# Altium schematic sheet Completo.SchDoc(Completo)
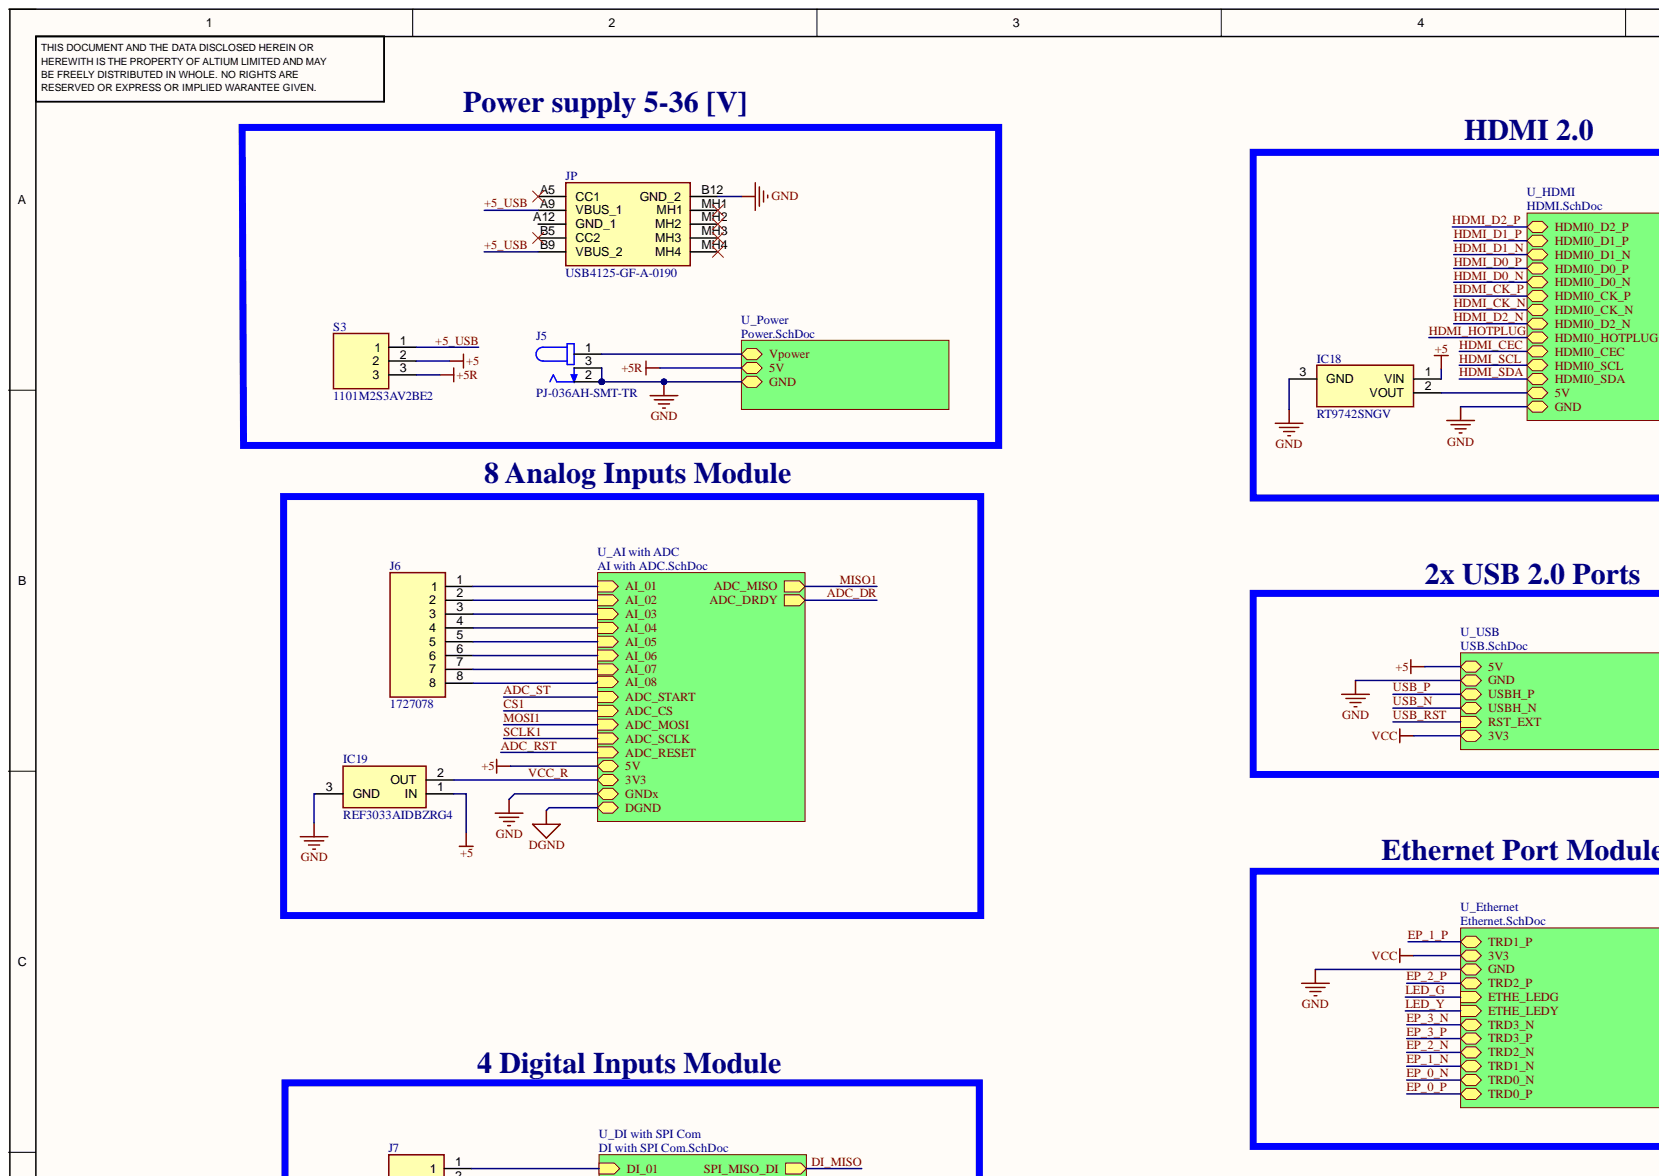
[diagram p0: Completo.SchDoc, top left]
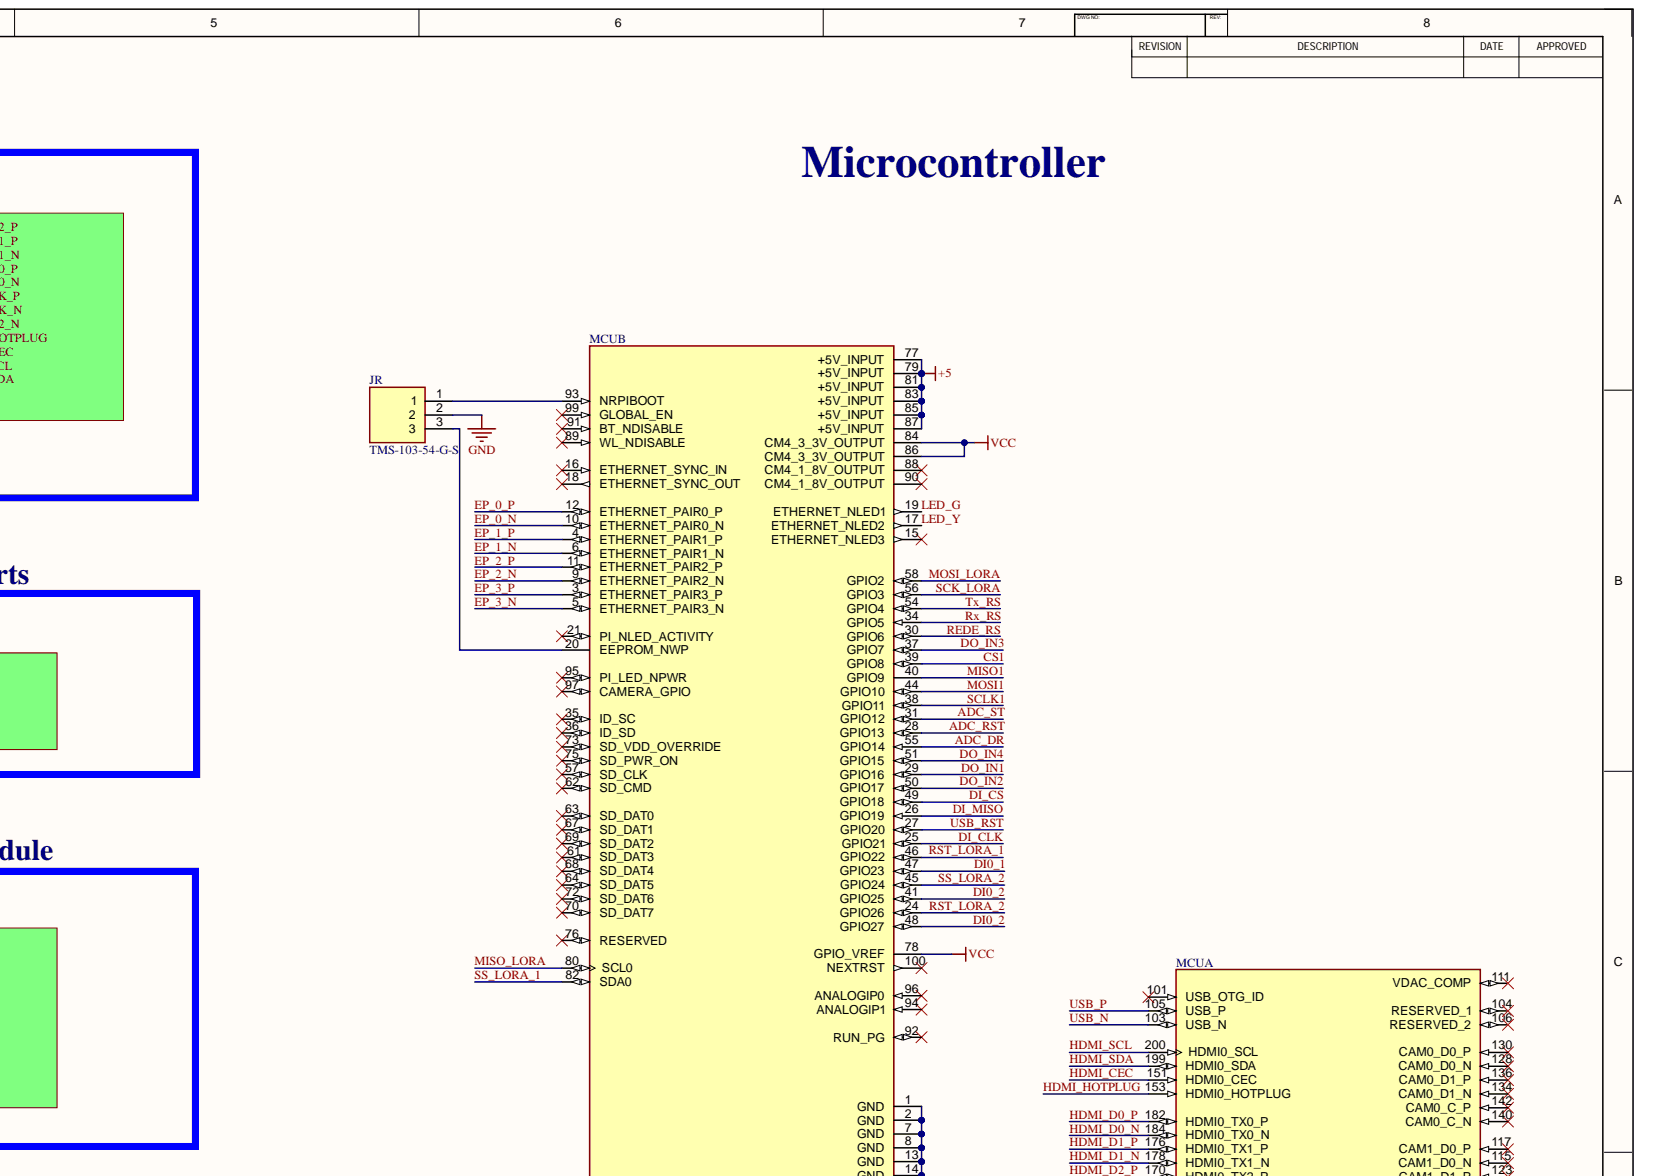
[diagram p1: Completo.SchDoc, top right]
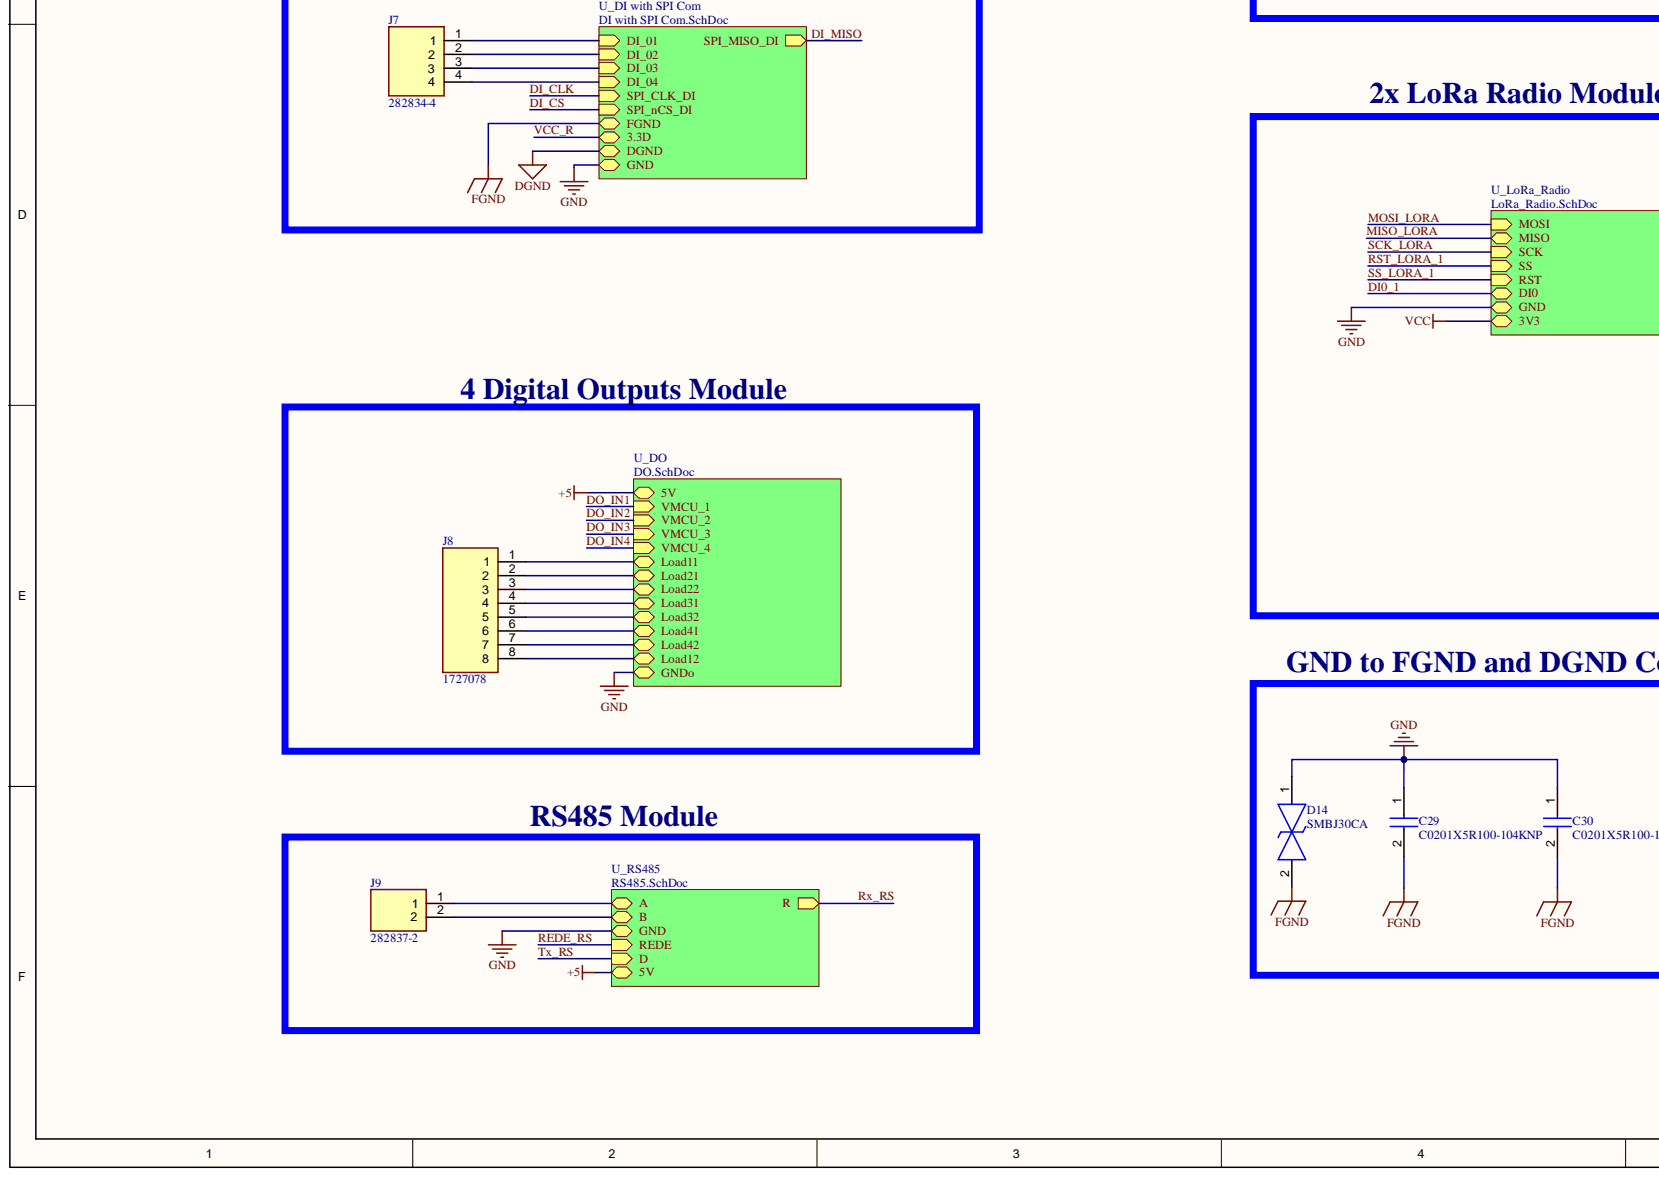
[diagram p2: Completo.SchDoc, bottom left]
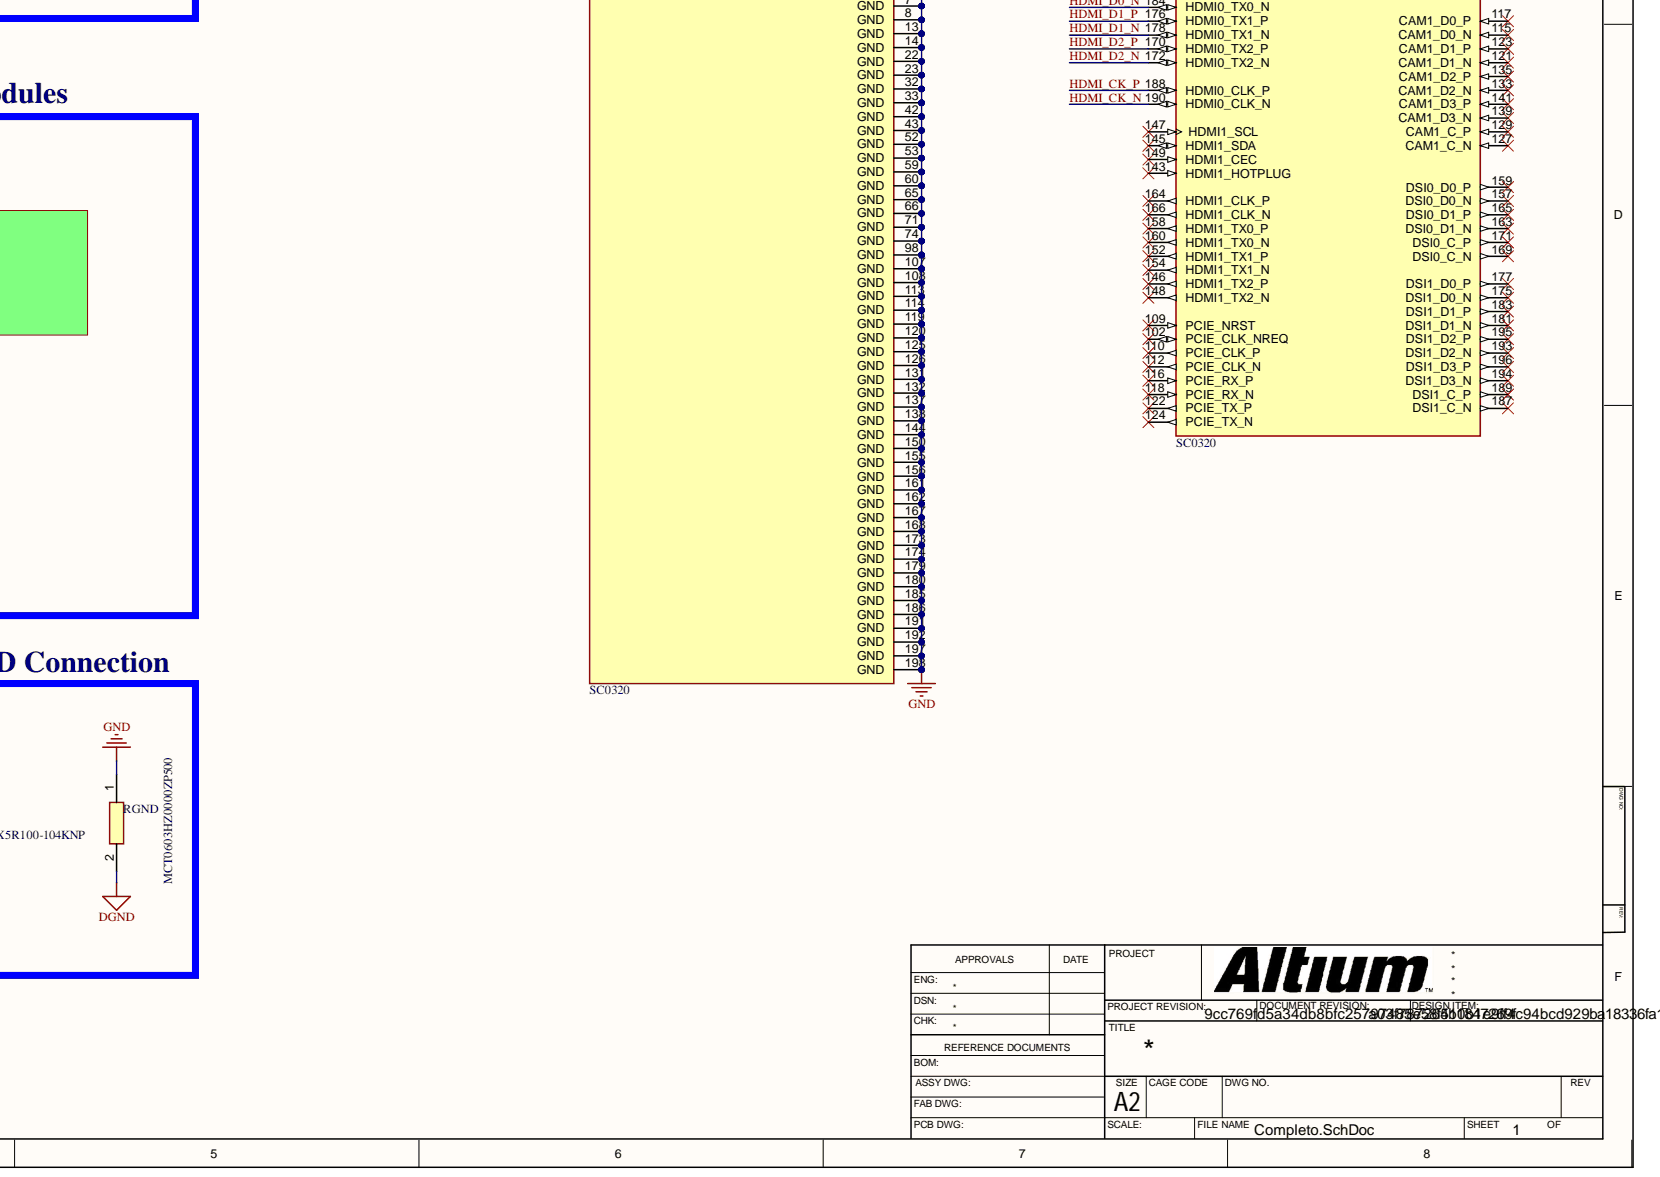
[diagram p3: Completo.SchDoc, bottom right]

## Parts
C29  C0201X5R100-104KNP  [CAPC0603X55N]
C30  C0201X5R100-104KNP  [CAPC0603X55N]
D14  SMBJ30CA  [DIOM5436X244N]
IC18  RT9742SNGV  [SOT95P279X130-3N]
IC19  REF3033AIDBZRG4  [SOT95P237X112-3N]
J5  PJ-036AH-SMT-TR  [CUI_PJ-036AH-SMT-TR]
J6  1727078  [1727078]
J7  282834-4  [TE_282834-4]
J8  1727078  [1727078]
J9  282837-2  [2828372]
JP  USB4125-GF-A-0190  [USB4125GFA0190]
JR  TMS-103-54-G-S  [TMS-103-YY-XX-S]
MCU  SC0320  [MODULE_SC0320]  (parts A,B)
RGND  MCT0603HZ0000ZP500  [RESC1608X55N]
S3  1101M2S3AV2BE2  [1000-SERIES(SPDT)]

## Nets
+5: IC18.1 IC19.1 MCU.77(+5V_INPUT) MCU.79(+5V_INPUT) MCU.81(+5V_INPUT) MCU.83(+5V_INPUT) MCU.85(+5V_INPUT) MCU.87(+5V_INPUT) S3.2
+5R: S3.3
DGND: RGND.2
FGND: C29.2 C30.2 D14.2
GND: C29.1 C30.1 D14.1 IC18.3 IC19.3 J5.2 J5.3 JP.B12(GND_2) JR.2 MCU.1(GND) MCU.2(GND) MCU.7(GND) MCU.8(GND) MCU.13(GND) MCU.14(GND) MCU.22(GND) MCU.23(GND) MCU.32(GND) MCU.33(GND) MCU.42(GND) MCU.43(GND) MCU.52(GND) MCU.53(GND) MCU.59(GND) MCU.60(GND) MCU.65(GND) MCU.66(GND) MCU.71(GND) MCU.74(GND) MCU.98(GND) MCU.107(GND) MCU.108(GND) MCU.113(GND) MCU.114(GND) MCU.119(GND) MCU.120(GND) MCU.125(GND) MCU.126(GND) MCU.131(GND) MCU.132(GND) MCU.137(GND) MCU.138(GND) MCU.144(GND) MCU.150(GND) MCU.155(GND) MCU.156(GND) MCU.161(GND) MCU.162(GND) MCU.167(GND) MCU.168(GND) MCU.173(GND) MCU.174(GND) MCU.179(GND) MCU.180(GND) MCU.185(GND) MCU.186(GND) MCU.191(GND) MCU.192(GND) MCU.197(GND) MCU.198(GND) RGND.1
VCC: MCU.78(GPIO_VREF) MCU.84(CM4_3_3V_OUTPUT) MCU.86(CM4_3_3V_OUTPUT)
+5_USB: JP.A9(VBUS_1) JP.B9(VBUS_2) S3.1
ADC_DR: MCU.55(GPIO14)
ADC_RST: MCU.28(GPIO13)
ADC_ST: MCU.31(GPIO12)
CS1: MCU.39(GPIO8)
DI0_1: MCU.47(GPIO23)
DI0_2: MCU.41(GPIO25) MCU.48(GPIO27)
DI_CLK: MCU.25(GPIO21)
DI_CS: MCU.49(GPIO18)
DI_MISO: MCU.26(GPIO19)
DO_IN1: MCU.29(GPIO16)
DO_IN2: MCU.50(GPIO17)
DO_IN3: MCU.37(GPIO7)
DO_IN4: MCU.51(GPIO15)
EP_0_N: MCU.10(ETHERNET_PAIR0_N)
EP_0_P: MCU.12(ETHERNET_PAIR0_P)
EP_1_N: MCU.6(ETHERNET_PAIR1_N)
EP_1_P: MCU.4(ETHERNET_PAIR1_P)
EP_2_N: MCU.9(ETHERNET_PAIR2_N)
EP_2_P: MCU.11(ETHERNET_PAIR2_P)
EP_3_N: MCU.5(ETHERNET_PAIR3_N)
EP_3_P: MCU.3(ETHERNET_PAIR3_P)
HDMI_CEC: MCU.151(HDMI0_CEC)
HDMI_CK_N: MCU.190(HDMI0_CLK_N)
HDMI_CK_P: MCU.188(HDMI0_CLK_P)
HDMI_D0_N: MCU.184(HDMI0_TX0_N)
HDMI_D0_P: MCU.182(HDMI0_TX0_P)
HDMI_D1_N: MCU.178(HDMI0_TX1_N)
HDMI_D1_P: MCU.176(HDMI0_TX1_P)
HDMI_D2_N: MCU.172(HDMI0_TX2_N)
HDMI_D2_P: MCU.170(HDMI0_TX2_P)
HDMI_HOTPLUG: MCU.153(HDMI0_HOTPLUG)
HDMI_SCL: MCU.200(HDMI0_SCL)
HDMI_SDA: MCU.199(HDMI0_SDA)
LED_G: MCU.19(ETHERNET_NLED1)
LED_Y: MCU.17(ETHERNET_NLED2)
MISO1: MCU.40(GPIO9)
MISO_LORA: MCU.80(SCL0)
MOSI1: MCU.44(GPIO10)
MOSI_LORA: MCU.58(GPIO2)
REDE_RS: MCU.30(GPIO6)
RST_LORA_1: MCU.46(GPIO22)
RST_LORA_2: MCU.24(GPIO26)
Rx_RS: MCU.34(GPIO5)
SCK_LORA: MCU.56(GPIO3)
SCLK1: MCU.38(GPIO11)
SS_LORA_1: MCU.82(SDA0)
SS_LORA_2: MCU.45(GPIO24)
Tx_RS: MCU.54(GPIO4)
USB_N: MCU.103(USB_N)
USB_P: MCU.105(USB_P)
USB_RST: MCU.27(GPIO20)
VCC_R: IC19.2
NetIC18_2: IC18.2
NetJ5_1: J5.1
NetJ6_1: J6.1
NetJ6_2: J6.2
NetJ6_3: J6.3
NetJ6_4: J6.4
NetJ6_5: J6.5
NetJ6_6: J6.6
NetJ6_7: J6.7
NetJ6_8: J6.8
NetJ7_1: J7.1
NetJ7_2: J7.2
NetJ7_3: J7.3
NetJ7_4: J7.4
NetJ8_1: J8.1
NetJ8_2: J8.2
NetJ8_3: J8.3
NetJ8_4: J8.4
NetJ8_5: J8.5
NetJ8_6: J8.6
NetJ8_7: J8.7
NetJ8_8: J8.8
NetJ9_1: J9.1
NetJ9_2: J9.2
NetJP_A5: JP.A5(CC1)
NetJP_A12: JP.A12(GND_1)
NetJP_B5: JP.B5(CC2)
NetJP_MH1: JP.MH1
NetJP_MH2: JP.MH2
NetJP_MH3: JP.MH3
NetJP_MH4: JP.MH4
NetJR_1: JR.1 MCU.93(NRPIBOOT)
NetJR_3: JR.3 MCU.20(EEPROM_NWP)
NetMCU_15: MCU.15(ETHERNET_NLED3)
NetMCU_16: MCU.16(ETHERNET_SYNC_IN)
NetMCU_18: MCU.18(ETHERNET_SYNC_OUT)
NetMCU_21: MCU.21(PI_NLED_ACTIVITY)
NetMCU_35: MCU.35(ID_SC)
NetMCU_36: MCU.36(ID_SD)
NetMCU_57: MCU.57(SD_CLK)
NetMCU_61: MCU.61(SD_DAT3)
NetMCU_62: MCU.62(SD_CMD)
NetMCU_63: MCU.63(SD_DAT0)
NetMCU_64: MCU.64(SD_DAT5)
NetMCU_67: MCU.67(SD_DAT1)
NetMCU_68: MCU.68(SD_DAT4)
NetMCU_69: MCU.69(SD_DAT2)
NetMCU_70: MCU.70(SD_DAT7)
NetMCU_72: MCU.72(SD_DAT6)
NetMCU_73: MCU.73(SD_VDD_OVERRIDE)
NetMCU_75: MCU.75(SD_PWR_ON)
NetMCU_76: MCU.76(RESERVED)
NetMCU_88: MCU.88(CM4_1_8V_OUTPUT)
NetMCU_89: MCU.89(WL_NDISABLE)
NetMCU_90: MCU.90(CM4_1_8V_OUTPUT)
NetMCU_91: MCU.91(BT_NDISABLE)
NetMCU_92: MCU.92(RUN_PG)
NetMCU_94: MCU.94(ANALOGIP1)
NetMCU_95: MCU.95(PI_LED_NPWR)
NetMCU_96: MCU.96(ANALOGIP0)
NetMCU_97: MCU.97(CAMERA_GPIO)
NetMCU_99: MCU.99(GLOBAL_EN)
NetMCU_100: MCU.100(NEXTRST)
NetMCU_101: MCU.101(USB_OTG_ID)
NetMCU_102: MCU.102(PCIE_CLK_NREQ)
NetMCU_104: MCU.104(RESERVED_1)
NetMCU_106: MCU.106(RESERVED_2)
NetMCU_109: MCU.109(PCIE_NRST)
NetMCU_110: MCU.110(PCIE_CLK_P)
NetMCU_111: MCU.111(VDAC_COMP)
NetMCU_112: MCU.112(PCIE_CLK_N)
NetMCU_115: MCU.115(CAM1_D0_N)
NetMCU_116: MCU.116(PCIE_RX_P)
NetMCU_117: MCU.117(CAM1_D0_P)
NetMCU_118: MCU.118(PCIE_RX_N)
NetMCU_121: MCU.121(CAM1_D1_N)
NetMCU_122: MCU.122(PCIE_TX_P)
NetMCU_123: MCU.123(CAM1_D1_P)
NetMCU_124: MCU.124(PCIE_TX_N)
NetMCU_127: MCU.127(CAM1_C_N)
NetMCU_128: MCU.128(CAM0_D0_N)
NetMCU_129: MCU.129(CAM1_C_P)
NetMCU_130: MCU.130(CAM0_D0_P)
NetMCU_133: MCU.133(CAM1_D2_N)
NetMCU_134: MCU.134(CAM0_D1_N)
NetMCU_135: MCU.135(CAM1_D2_P)
NetMCU_136: MCU.136(CAM0_D1_P)
NetMCU_139: MCU.139(CAM1_D3_N)
NetMCU_140: MCU.140(CAM0_C_N)
NetMCU_141: MCU.141(CAM1_D3_P)
NetMCU_142: MCU.142(CAM0_C_P)
NetMCU_143: MCU.143(HDMI1_HOTPLUG)
NetMCU_145: MCU.145(HDMI1_SDA)
NetMCU_146: MCU.146(HDMI1_TX2_P)
NetMCU_147: MCU.147(HDMI1_SCL)
NetMCU_148: MCU.148(HDMI1_TX2_N)
NetMCU_149: MCU.149(HDMI1_CEC)
NetMCU_152: MCU.152(HDMI1_TX1_P)
NetMCU_154: MCU.154(HDMI1_TX1_N)
NetMCU_157: MCU.157(DSI0_D0_N)
NetMCU_158: MCU.158(HDMI1_TX0_P)
NetMCU_159: MCU.159(DSI0_D0_P)
NetMCU_160: MCU.160(HDMI1_TX0_N)
NetMCU_163: MCU.163(DSI0_D1_N)
NetMCU_164: MCU.164(HDMI1_CLK_P)
NetMCU_165: MCU.165(DSI0_D1_P)
NetMCU_166: MCU.166(HDMI1_CLK_N)
NetMCU_169: MCU.169(DSI0_C_N)
NetMCU_171: MCU.171(DSI0_C_P)
NetMCU_175: MCU.175(DSI1_D0_N)
NetMCU_177: MCU.177(DSI1_D0_P)
NetMCU_181: MCU.181(DSI1_D1_N)
NetMCU_183: MCU.183(DSI1_D1_P)
NetMCU_187: MCU.187(DSI1_C_N)
NetMCU_189: MCU.189(DSI1_C_P)
NetMCU_193: MCU.193(DSI1_D2_N)
NetMCU_194: MCU.194(DSI1_D3_N)
NetMCU_195: MCU.195(DSI1_D2_P)
NetMCU_196: MCU.196(DSI1_D3_P)

## Library data of the parts
C29 C30 = C0201X5R100-104KNP: Capacitor; Manufacturer_Name: Venkel; Component Kind: Standard; Datasheet Link: https://venkelecd.com/partnumber/datasheet/C0201X5R100-104KNP; Height: 0.549mm; Library Name: SamacSys.SchLib; Manufacturer_Part_Number: C0201X5R100-104KNP
D14 = SMBJ30CA: TVS Diode (Bi-directional); Manufacturer_Name: LITTELFUSE; Arrow Part Number: SMBJ30CA; Arrow Price/Stock: https://www.arrow.com/en/products/smbj30ca/littelfuse?region=nac; Component Kind: Standard; Datasheet Link: https://componentsearchengine.com/Datasheets/2/SMBJ30CA.pdf; Height: 2.44mm; Library Name: SamacSys.SchLib; Manufacturer_Part_Number: SMBJ30CA; Mouser Part Number: 576-SMBJ30CA; Mouser Price/Stock: https://www.mouser.co.uk/ProductDetail/Littelfuse/SMBJ30CA?qs=HR2RnyOI4E5ZKjtlv2hDrQ%3D%3D
IC18 = RT9742SNGV: Integrated Circuit; Manufacturer_Name: RICHTEK; Component Kind: Standard; Datasheet Link: https://datasheet.lcsc.com/szlcsc/Richtek-Tech-RT9742ANGJ5F_C147955.pdf; Height: 1.295mm; Library Name: SamacSys.SchLib; Manufacturer_Part_Number: RT9742SNGV
IC19 = REF3033AIDBZRG4: Integrated Circuit; Manufacturer_Name: Texas Instruments; Arrow Part Number: REF3033AIDBZRG4; Arrow Price/Stock: https://www.arrow.com/en/products/ref3033aidbzrg4/texas-instruments; Component Kind: Standard; Datasheet Link: https://www.ti.com/lit/ds/symlink/ref3030.pdf?ts=1639296719724; Height: 1.12mm; Library Name: SamacSys.SchLib; Manufacturer_Part_Number: REF3033AIDBZRG4; Mouser Part Number: 595-REF3033AIDBZRG4; Mouser Price/Stock: https://www.mouser.co.uk/ProductDetail/Texas-Instruments/REF3033AIDBZRG4?qs=sSOk4GDDv7x3KhdEagQjiQ%3D%3D
J5 = PJ-036AH-SMT-TR: 2.0 mm Center Pin, 5.0 A, Right Angle, Surface Mount (SMT), Dc Power Jack Connector; Availability: Unavailable; Component Kind: Standard; Library Name: PJ-036AH-SMT-TR.SchLib; MP: PJ-036AH-SMT-TR; Package: None; Price: None
J6 J8 = 1727078: Connector; Manufacturer_Name: Phoenix Contact; Arrow Part Number: 1727078; Arrow Price/Stock: https://www.arrow.com/en/products/1727078/phoenix-contact?region=nac; Component Kind: Standard; Datasheet Link: https://componentsearchengine.com/Datasheets/2/1727078.pdf; Height: 8.65mm; Library Name: SamacSys.SchLib; Manufacturer_Part_Number: 1727078; Mouser Part Number: 651-1727078; Mouser Price/Stock: https://www.mouser.co.uk/ProductDetail/Phoenix-Contact/1727078?qs=JcjeNNoqGSNsjTv9gJgF1A%3D%3D
J7 = 282834-4: 4 Position Wire to Board Terminal Block Horizontal with Board 0.100 (2.54mm) Through Hole; MF: TE Connectivity; Availability: In Stock; Check_prices: https://www.snapeda.com/parts/282834-4/TE+Connectivity+AMP+Connectors/view-part/?ref=eda; Component Kind: Standard; EU_RoHS_Compliance: Compliant with Exemptions; Library Name: 282834-4.SchLib; MP: 282834-4; Package: None; Price: None; Purchase-URL: https://pricing.snapeda.com/search/part/282834-4/?ref=eda; SnapEDA_Link: https://www.snapeda.com/parts/282834-4/TE+Connectivity+AMP+Connectors/view-part/?ref=snap
J9 = 282837-2: Connector; Manufacturer_Name: TE Connectivity; Arrow Part Number: 282837-2; Arrow Price/Stock: https://www.arrow.com/en/products/282837-2/te-connectivity?region=europe; Component Kind: Standard; Datasheet Link: https://www.te.com/commerce/DocumentDelivery/DDEController?Action=srchrtrv&DocNm=282837&DocType=Customer+Drawing&DocLang=English&DocFormat=pdf&PartCntxt=282837-2; Height: 10.3mm; Library Name: SamacSys.SchLib; Manufacturer_Part_Number: 282837-2; Mouser Part Number: 571-2828372; Mouser Price/Stock: https://www.mouser.co.uk/ProductDetail/TE-Connectivity/282837-2?qs=A%252Bip%252BNCYi6O2H0NGWOeAxg%3D%3D
JP = USB4125-GF-A-0190: Connector; Manufacturer_Name: GCT (GLOBAL CONNECTOR TECHNOLOGY); Component Kind: Standard; Datasheet Link: https://eu.mouser.com/datasheet/2/837/usb4125-2448699.pdf; Library Name: SamacSys.SchLib; Manufacturer_Part_Number: USB4125-GF-A-0190; Mouser Part Number: 640-USB4125-GF-A-190; Mouser Price/Stock: https://www.mouser.co.uk/ProductDetail/GCT/USB4125-GF-A-0190?qs=QNEnbhJQKvbCz4hEJBS24w%3D%3D
JR = TMS-103-54-G-S: Connector; Manufacturer_Name: SAMTEC; Component Kind: Standard; Datasheet Link: http://suddendocs.samtec.com/prints/tms-1xx-xx-xx-x-xx-xxx-mkt.pdf; Library Name: SamacSys.SchLib; Manufacturer_Part_Number: TMS-103-54-G-S
MCU = SC0320: COMPUTE 4 1GB RAM 16GB EMMC; MF: Raspberry Pi; Availability: Bad; Check_prices: https://www.snapeda.com/parts/SC0320/Raspberry%20Pi/view-part/5507680/?ref=eda; Component Kind: Standard; Library Name: SC0320.SchLib; MP: SC0320; Package: None; Price: None; Purchase-URL: https://pricing.snapeda.com/search/part/SC0320/?ref=eda; SnapEDA_Link: https://www.snapeda.com/parts/SC0320/Raspberry%20Pi/view-part/5507680/?ref=snap
RGND = MCT0603HZ0000ZP500: Resistor; Manufacturer_Name: Vishay; Arrow Part Number: MCT0603HZ0000ZP500; Arrow Price/Stock: https://www.arrow.com/en/products/mct0603hz0000zp500/vishay?region=nac; Component Kind: Standard; Datasheet Link: http://www.vishay.com/docs/28705/mcx0x0xpro.pdf; Height: 0.55mm; Manufacturer_Part_Number: MCT0603HZ0000ZP500; Mouser Part Number: 594-MCT0603HZ0000ZP5; Mouser Price/Stock: https://www.mouser.co.uk/ProductDetail/Vishay-Beyschlag/MCT0603HZ0000ZP500?qs=xy8ZAJ6gwchPwuxyJv%252BYaw%3D%3D
S3 = 1101M2S3AV2BE2: Switch; Manufacturer_Name: C & K COMPONENTS; Arrow Part Number: 1101M2S3AV2BE2; Arrow Price/Stock: https://www.arrow.com/en/products/1101m2s3av2be2/ck?region=europe; Component Kind: Standard; Datasheet Link: https://www.ckswitches.com/media/1429/1000.pdf; Library Name: SamacSys.SchLib; Manufacturer_Part_Number: 1101M2S3AV2BE2; Mouser Part Number: 611-1101M2S3AV2BE2; Mouser Price/Stock: https://www.mouser.co.uk/ProductDetail/CK/1101M2S3AV2BE2?qs=oIfnSaRkEUkO7A7aJtnxew%3D%3D
